# Altium schematic sheet PAGE03_机场端单片机.SchDoc
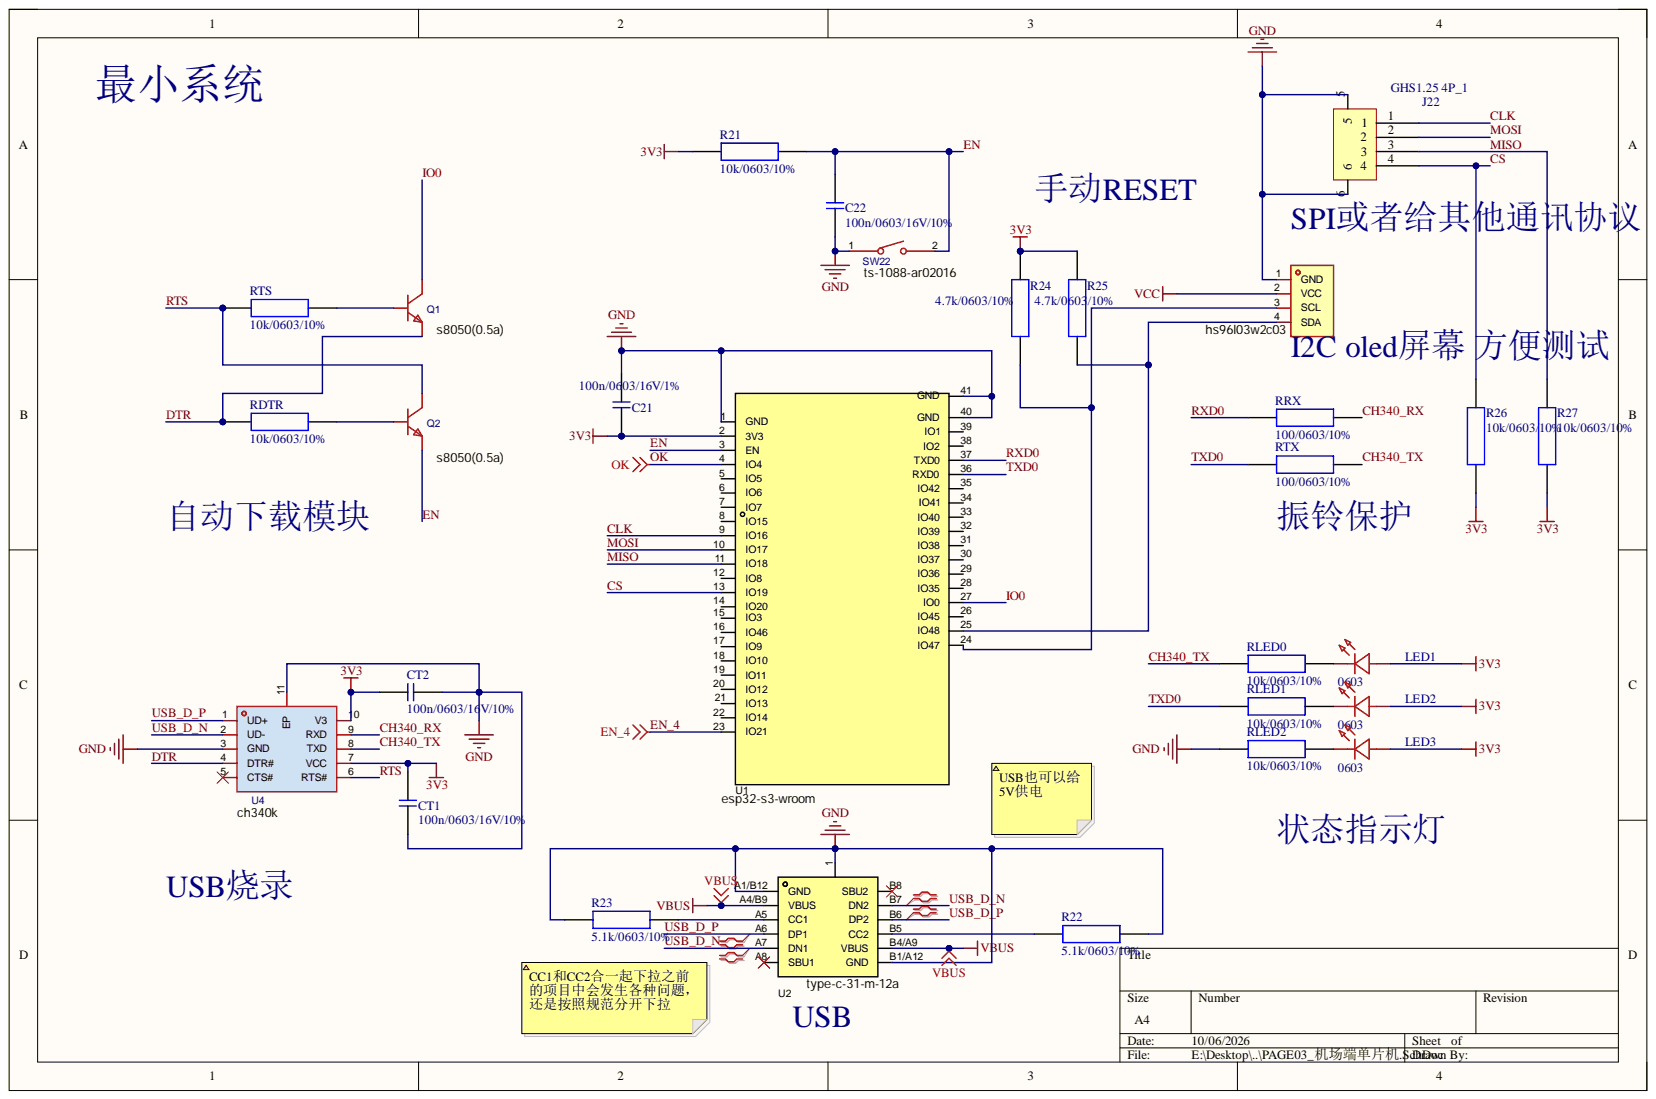
[diagram p0: PAGE03_机场端单片机.SchDoc]

## Parts
C21  100n/0603/16V/1%  [0603_ding_C]
C22  100n/0603/16V/10%  [0603_ding_C]
CT1  100n/0603/16V/10%  [0603_ding_C]
CT2  100n/0603/16V/10%  [0603_ding_C]
J22  GHS1.25 4P_1  [SM04B-GHS卧式]
LED1  0603  [LED0603-RD_GREEN]
LED2  0603  [LED0603-RD_GREEN]
LED3  0603  [LED0603-RD_GREEN]
Q1  s8050(0.5a)  [SOT-23-3_L2.9-W1.3-P1.90-LS2.4-BR]
Q2  s8050(0.5a)  [SOT-23-3_L2.9-W1.3-P1.90-LS2.4-BR]
R21  10k/0603/10%  [0603_ding_R]
R22  5.1k/0603/10%  [0603_ding_R]
R23  5.1k/0603/10%  [0603_ding_R]
R24  4.7k/0603/10%  [0603_ding_R]
R25  4.7k/0603/10%  [0603_ding_R]
R26  10k/0603/10%  [0603_ding_R]
R27  10k/0603/10%  [0603_ding_R]
RDTR  10k/0603/10%  [0603_ding_R]
RLED0  10k/0603/10%  [0603_ding_R]
RLED1  10k/0603/10%  [0603_ding_R]
RLED2  10k/0603/10%  [0603_ding_R]
RRX  100/0603/10%  [0603_ding_R]
RTS  10k/0603/10%  [0603_ding_R]
RTX  100/0603/10%  [0603_ding_R]
SW22  ts-1088-ar02016  [SW-SMD_L3.9-W3.0-P4.45]
U1  esp32-s3-wroom  [WIRELM-SMD_ESP32-S3-WROOM-1]
U2  type-c-31-m-12a  [USB-C-SMD_TYPE-C-31-M-12A]
U4  ch340k  [ESOP-10_L4.9-W3.9-P1.00-LS6.2-BL-EP]
U22  hs96l03w2c03  Interface: I2C  [OLED-TH_L27.8-W27.2-P2.54_C9900033791]

## Nets
3V3: C21.1 CT1.2 CT2.1 LED1.2 LED2.2 LED3.2 R21.1 R24.2 R25.2 R26.1 R27.1 U1.2(3V3) U4.7(VCC) U4.10(V3)
GND: C21.2 C22.1 CT1.1 CT2.2 J22.5 J22.6 R22.1 R23.1 RLED2.1 SW22.1 U1.1(GND) U1.40(GND) U1.41(GND) U2.1 U2.A1/B12(GND) U2.B1/A12(GND) U4.3(GND) U4.11(EP) U22.1(GND)
VBUS: U2.A4/B9(VBUS) U2.B4/A9(VBUS)
VCC: U22.2(VCC)
CH340_RX: RRX.2 U4.9(RXD)
CH340_TX: RLED0.1 RTX.2 U4.8(TXD)
CLK: J22.1 U1.9(IO16)
CS: J22.4 R26.2 U1.13(IO19)
DTR: Q1.2 RDTR.1 U4.4(DTR#)
EN: C22.2 Q2.2 R21.2 SW22.2 U1.3(EN)
EN_4: U1.23(IO21)
IO0: Q1.3 U1.27(IO0)
MISO: J22.3 R27.2 U1.11(IO18)
MOSI: J22.2 U1.10(IO17)
OK: U1.4(IO4)
RTS: Q2.3 RTS.1 U4.6(RTS#)
RXD0: RRX.1 U1.37(TXD0)
TXD0: RLED1.1 RTX.1 U1.36(RXD0)
USB_D_N: U2.A7(DN1) U2.B7(DN2) U4.2(UD-)
USB_D_P: U2.A6(DP1) U2.B6(DP2) U4.1(UD+)
NetLED1_1: LED1.1 RLED0.2
NetLED2_1: LED2.1 RLED1.2
NetLED3_1: LED3.1 RLED2.2
NetQ1_1: Q1.1 RTS.2
NetQ2_1: Q2.1 RDTR.2
NetR22_2: R22.2 U2.B5(CC2)
NetR23_2: R23.2 U2.A5(CC1)
NetR24_1: R24.1 U1.24(IO47) U22.3(SCL)
NetR25_1: R25.1 U1.25(IO48) U22.4(SDA)

Not connected: U1 5(IO5) 6(IO6) 7(IO7) 8(IO15) 12(IO8) 14(IO20) 15(IO3) 16(IO46) 17(IO9) 18(IO10) 19(IO11) 20(IO12) 21(IO13) 22(IO14) 26(IO45) 28(IO35) 29(IO36) 30(IO37) 31(IO38) 32(IO39) 33(IO40) 34(IO41) 35(IO42) 38(IO2) 39(IO1); U2 A8(SBU1) B8(SBU2); U4 5(CTS#)

## Library data of the parts
C21 C22 CT1 CT2 = C: Component Kind: Standard; Library Name: IP6826.SchLib
J22 = GHS1.25 4P_1: 卧式短边; Component Kind: Standard; Library Name: RobotLab_Common_Used.SchLib
LED1-LED3 = LED0603: Component Kind: Standard; Library Name: IP6826.SchLib
Q1 Q2 = s8050(0.5a): 晶体管类型:NPN;集电极电流(Ic):500mA;集射极击穿电压(Vceo):25V;耗散功率(Pd):300mW;直流电流增益(hFE):120;; Manufacturer: EIC; AddInBom: yes; Collector - Emitter Voltage VCEO: 25V; Current - Collector Cutoff: 100nA; Current - Collector(Ic): 500mA; DC Current Gain: 120; Emitter-Base Voltage(Vebo): 5V; ModelId: c62791f0466c4ae2a892c056b845b0ca; ModelName: SOT-23-3P_L2.9-W1.3-H1.0-LS2.4-P0.95; ModelTransform: 98.425,114.173,0,180,0,0,0.0005,-0.0005,0; Number: 1个NPN; Pd - Power Dissipation: 300mW; Transition frequency(fT): 150MHz; type: NPN; Vce Saturation(VCE(sat)): 600mV; Component Kind: Standard; ConvertToPcb: yes; Datasheet: https://item.szlcsc.com/datasheet/S8050(0.5A)/3524496.html; FootprintName: SOT-23-3_L2.9-W1.3-P1.90-LS2.4-BR; JLCPCB Part Class: Extended Part; LCSC Part Name: 电流:0.5A 电压:25V; Library Name: S8050(0.5A).SCHLIB; ManufacturerPart: S8050(0.5A); Supplier: LCSC; Supplier Footprint: SOT-23; SupplierPart: C3014350; Symbol: S8050(0.5A)
R21-R27 RDTR RLED0-RLED2 RRX RTS RTX = RES: Component Kind: Standard; Library Name: IP6826.SchLib
SW22 = ts-1088-ar02016: 电路结构:单刀单掷;按钮形状:圆形按钮;作用力:1.6N;安装方式:立贴;开关长度:3.9mm;开关宽度:3mm;开关高度:2mm;带支架:不带支架;触点电流:50mA;额定电压:12V;带灯:-;工作寿命:10万次;; Manufacturer: XUNPU(讯普); Actuator Style: 圆形按钮; Actuator/Cap Color: 黑色; AddInBom: yes; Circuit: 单刀单掷; Contact Current: 50mA; Life: 10万次; ModelId: b26698b69f274ffabef8a26c7d0e5dd1; ModelName: SW-SMD_L3.9-W2.9-H2.0-LS4.8; ModelTransform: 188.976,114.174,0,0,0,0,0,0,0; Operating Force: 1.6N; Switch Height: 2mm; Voltage Rating: 12V; with Bracket: 不带支架; Component Kind: Standard; ConvertToPcb: yes; Datasheet: https://item.szlcsc.com/datasheet/TS-1088-AR02016/763484.html; FootprintName: SW-SMD_L3.9-W3.0-P4.45; IP Rating: -; JLCPCB Part Class: Basic Part; LCSC Part Name: 3.9*3*2mm 立贴 轻触开关; Length: 3.9mm; Library Name: TS-1088-AR02016.SCHLIB; ManufacturerPart: TS-1088-AR02016; Mounting Type: 立贴; Operating Temperature: -30℃~+80℃; Supplier: LCSC; Supplier Footprint: SMD,4x3mm; SupplierPart: C720477; Symbol: TS-1088-AR02016; Termination Style: SMD接片; Width: 3mm; With Lamp: -
U1 = esp32-s3-wroom-1-n16r8: 核心芯片:ESP32-S3R8;频率:2.4GHz;天线形式:板载PCB天线;发射功率:20.5dBm;接收灵敏度:-103.5dBm;; Manufacturer: ESPRESSIF(乐鑫); AddInBom: yes; Interface Type: I2C；GPIO；UART；SPI；USB；I2S; ModelId: 481f5bdfc076422c9bb3c23c3ada9c17; ModelTransform: 708.73866,1003.97437,0,0,0,0,0,143.7,0; Receive  Current: 95mA; Send  Current: 355mA; Symbol: ESP32-S3-WROOM-1(N16R8); Value: 2.4GHz; Voltage - Supply: 3V~3.6V; Antenna Type: 板载PCB天线; Component Kind: Standard; ConvertToPcb: yes; Datasheet: https://item.szlcsc.com/datasheet/ESP32-S3-WROOM-1-N16R8/3198300.html; FootprintName: WIRELM-SMD_ESP32-S3-WROOM-1; JLCPCB Part Class: Extended Part; LCSC Part Name: 不带固件 通用型Wi-Fi+低功耗蓝牙MCU模组; ManufacturerPart: ESP32-S3-WROOM-1-N16R8; ModelName: WIRELM-SMD_ESP32-S3-WROOM-1; Operating Temperature: -40℃~+65℃; Output Power: 20.5dBm; Sensitivity: -103.5dBm; Supplier: LCSC; Supplier Footprint: SMD,25.5x18mm; SupplierPart: C2913202; Utilized IC / Part: ESP32-S3R8; Library Name: feikong.SCHLIB
U2 = type-c-31-m-12a: 连接器类型:Type-C;公母:母;安装方式:卧贴;触点数量:16P;端口数量:1;额定电流 - 电源:6A;工作温度:-30℃~+85℃;; Manufacturer: 韩国韩荣; AddInBom: yes; Connect-Disconnect Life: 1万次; Connector Type: Type-C; Current Rating-Power: 6A; Gender: 母; ModelId: 5328bfb13ed649e8a9ad528bb3d92809; ModelTransform: 351.9678,305.1175,0,0,0,0,0,-41.575,-39.37; Number of Contacts: 16P; Number of Ports: 1; Voltage Rating: 20V; Component Kind: Standard; ConvertToPcb: yes; Datasheet: https://item.szlcsc.com/datasheet/TYPE-C-31-M-12A/6102654.html; FootprintName: USB-C-SMD_TYPE-C-31-M-12A; JLCPCB Part Class: Extended Part; LCSC Part Name: Type-C 母 卧贴; Length: 7.35mm; ManufacturerPart: TYPE-C-31-M-12A; ModelName: USB-C-SMD_TYPE-C-31-M-12A; Mounting Type: 卧贴; Operating Temperature: -30℃~+85℃; Supplier: LCSC; Supplier Footprint: SMD; SupplierPart: C5337088; Symbol: TYPE-C-31-M-12A; Library Name: feikong_1.SCHLIB
U4 = ch340k: 应用功能:USB转串口;USB协议版本:USB 2.0;通道数:-;数据速率:2Mbps;; Manufacturer: WCH(南京沁恒); AddInBom: yes; Applications Function: USB转串口; Data Rate: 2Mbps; ModelId: 154218974c894ddbb70273feac157d69; ModelName: ESOP-10_L4.9-W3.9-H1.4-P1.00-LS6.2-BL-EP; ModelTransform: 192.913,242.1255,0,0,0,0,0,0,0; USB Protocol: USB 2.0; Voltage - Supply: 3.3V；5V; Component Kind: Standard; ConvertToPcb: yes; Datasheet: https://item.szlcsc.com/datasheet/CH340K/1056391.html; Features: 可编程波特率；内置时钟发生器；断电保护；上电复位; FootprintName: ESOP-10_L4.9-W3.9-P1.00-LS6.2-BL-EP; JLCPCB Part Class: Extended Part; LCSC Part Name: USB转串口芯片CH340; Library Name: CH340K.SCHLIB; ManufacturerPart: CH340K; Number of Channels: -; Operating Temperature: -20℃~+70℃; Supplier: LCSC; Supplier Footprint: ESSOP-10-150mil-1mm; SupplierPart: C968586; Symbol: CH340K
U22 = hs96l03w2c03: 主控芯片:SSD1315芯片;接口类型:I2C;尺寸(inch):0.96;像素分辨率:128x64;; Manufacturer: HS(汉昇); AddInBom: yes; Display Color: 白色; Display Range: 21.744x10.864mm; Dot Pixels: 128x64; Luminance: 180cd/m2; ModelId: bb65183306db4ca69fb52250be49b00e; ModelTransform: 1070.864,1094.486,0,0,0,0,0,-498.424,0; Type of Drive: 无源(PMOLED); Component Kind: Standard; Controller Type: SSD1315芯片; ConvertToPcb: yes; Datasheet: https://item.szlcsc.com/datasheet/HS96L03W2C03/5960631.html; FootprintName: OLED-TH_L27.8-W27.2-P2.54_C9900033791; Function: -; JLCPCB Part Class: Extended Part; LCSC Part Name: 0.96寸OLED显示屏窄白光IIC; Library Name: HS96L03W2C03.SCHLIB; ManufacturerPart: HS96L03W2C03; ModelName: OLED-TH_L27.8-W27.2-P2.54_C9900033791; Operating Temperature: -40℃~+70℃; Quiescent Current: -; Size: 0.96; Supplier: LCSC; Supplier Footprint: -; SupplierPart: C5248080; Symbol: HS96L03W2C03
